# Altium schematic sheet ESP32.SchDoc(ESP32)
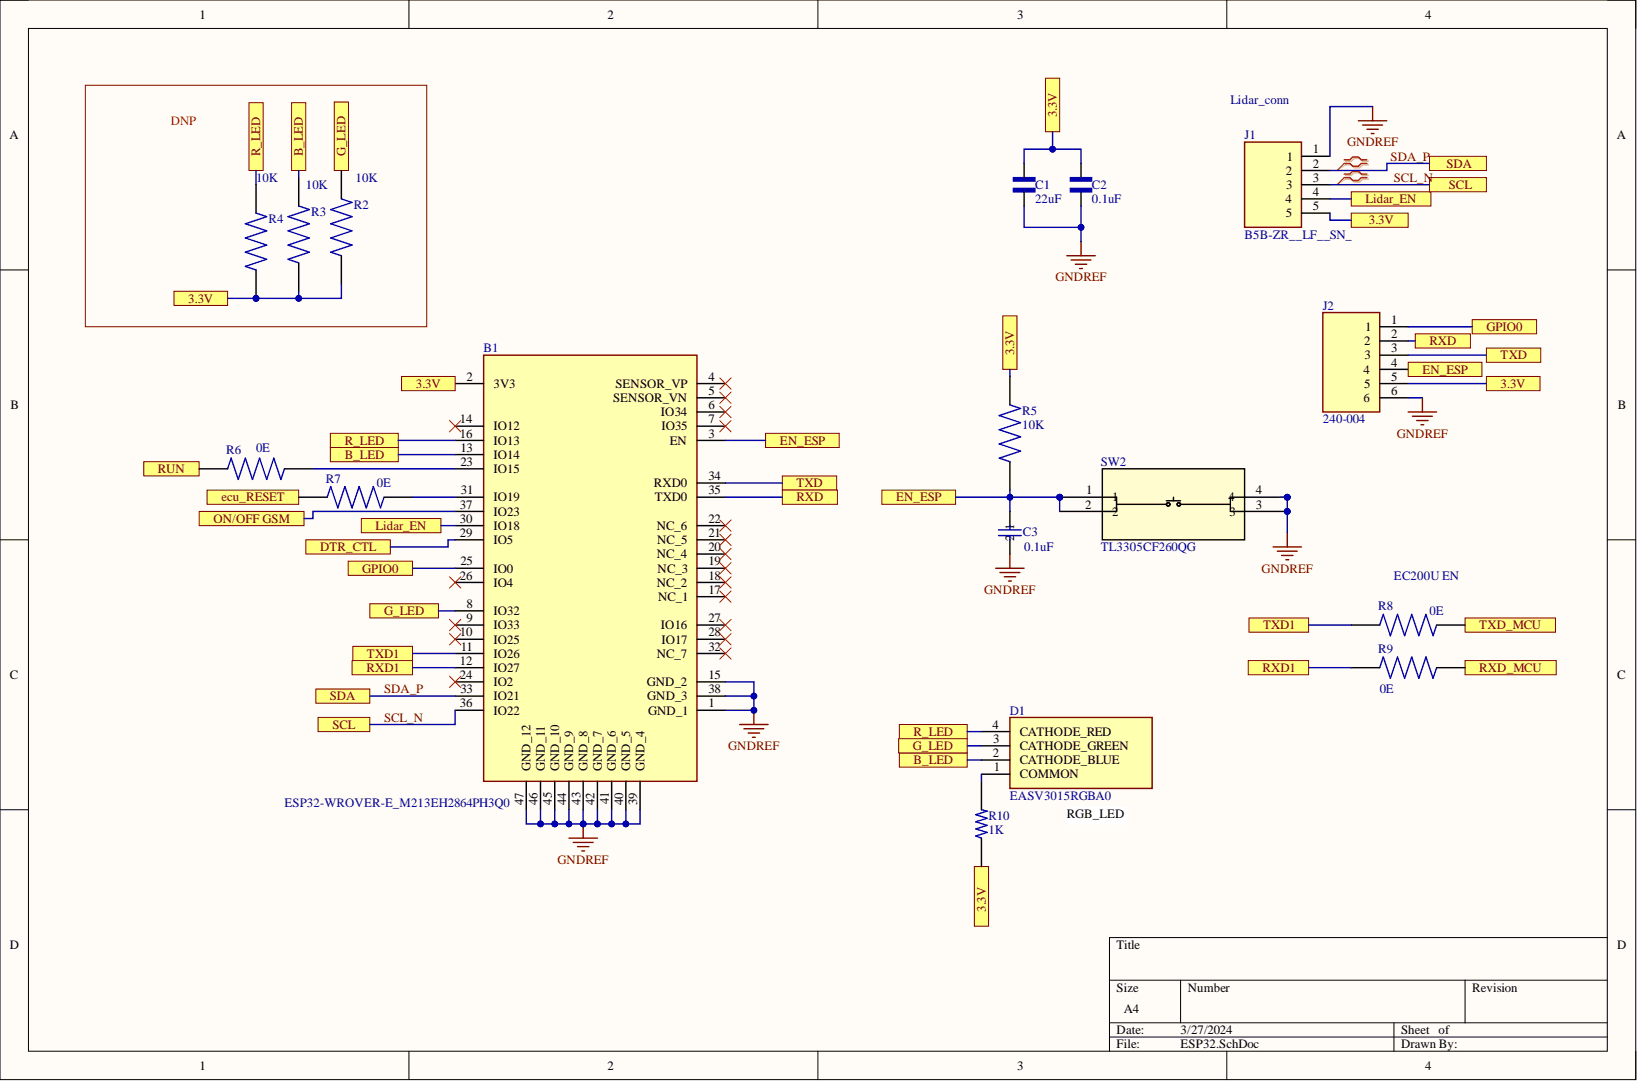
[diagram p0: ESP32.SchDoc]

## Parts
B1  ESP32-WROVER-E_M213EH2864PH3Q0
C1  22uF
C2  0.1uF
C3  0.1uF
D1  EASV3015RGBA0
J1  B5B-ZR__LF__SN_
J2  240-004
R2  10K
R3  10K
R4  10K
R5  10K
R6  0E
R7  0E
R8  0E
R9  0E
R10  1K
SW2  TL3305CF260QG

## Ports
3.3V, B_LED, DTR_CTL, ecu_RESET, EN_ESP, G_LED, GPIO0, Lidar_EN, ON/OFF GSM, R_LED, RUN, RXD, RXD1, RXD_MCU, SCL, SDA, TXD, TXD1, TXD_MCU

## Nets
GNDREF: B1.1(GND_1) B1.15(GND_2) B1.38(GND_3) B1.39(GND_4) B1.40(GND_5) B1.41(GND_6) B1.42(GND_7) B1.43(GND_8) B1.44(GND_9) B1.45(GND_10) B1.46(GND_11) B1.47(GND_12) C1.1 C2.1 C3.1 J1.1 J2.6 SW2.3 SW2.4
3.3V: B1.2(3V3) C1.2 C2.2 J1.5 J2.5 R2.2 R3.2 R4.2 R5.2 R10.1 ; port 3.3V
B_LED: B1.13(IO14) D1.2(CATHODE_BLUE) R3.1 ; port B_LED
DTR_CTL: B1.29(IO5) ; port DTR_CTL
ecu_RESET: R7.1 ; port ecu_RESET
EN_ESP: B1.3(EN) C3.2 J2.4 R5.1 SW2.1 SW2.2 ; port EN_ESP
G_LED: B1.8(IO32) D1.3(CATHODE_GREEN) R2.1 ; port G_LED
GPIO0: B1.25(IO0) J2.1 ; port GPIO0
Lidar_EN: B1.30(IO18) J1.4 ; port Lidar_EN
ON/OFF GSM: B1.37(IO23) ; port ON/OFF GSM
R_LED: B1.16(IO13) D1.4(CATHODE_RED) R4.1 ; port R_LED
RUN: R6.1 ; port RUN
RXD: B1.35(TXD0) J2.2 ; port RXD
RXD1: B1.12(IO27) R9.1 ; port RXD1
RXD_MCU: R9.2 ; port RXD_MCU
SCL_N: B1.36(IO22) J1.3 ; port SCL
SDA_P: B1.33(IO21) J1.2 ; port SDA
TXD: B1.34(RXD0) J2.3 ; port TXD
TXD1: B1.11(IO26) R8.1 ; port TXD1
TXD_MCU: R8.2 ; port TXD_MCU
NetB1_23: B1.23(IO15) R6.2
NetB1_31: B1.31(IO19) R7.2
NetD1_1: D1.1(COMMON) R10.2

Not connected: B1 4(SENSOR_VP) 5(SENSOR_VN) 6(IO34) 7(IO35) 9(IO33) 10(IO25) 14(IO12) 17(NC_1) 18(NC_2) 19(NC_3) 20(NC_4) 21(NC_5) 22(NC_6) 24(IO2) 26(IO4) 27(IO16) 28(IO17) 32(NC_7)

## Library data of the parts
B1 = ESP32-WROVER-E_M213EH2864PH3Q0: WiFi Modules (802.11) SMD Module ESP32-D0WD-V3, 3.3V 64Mbit PSRAM, 16 MB SPI flash, PCB Antenna; Manufacturer_Name: Espressif Systems; Manufacturer_Part_Number: ESP32-WROVER-E(M213EH2864PH3Q0); Geometry.Height: 3.45mm; Component Kind: Standard; Datasheet Link: https://www.mouser.in/datasheet/2/891/esp32-wrover-e_esp32-wrover-ie_datasheet_en-1855913.pdf; Library Name: ESP32-WROVER-E_M213EH2864PH3Q0.SchLib; Mouser Part Number: 356-ESP32WRVE22864PC; Mouser Price/Stock: https://www.mouser.co.uk/ProductDetail/Espressif-Systems/ESP32-WROVER-EM213EH2864PH3Q0?qs=vmHwEFxEFR8kG00CaGIvFQ%3D%3D
C1 = GRM188R61A226ME15D: SMD Capacitor X5R(EIA) with Capacitance: 22uF Tol. 20%. Rated Voltage: 10Vdc; MF: {'manufacturer': u'Murata Electronics'}; Availability: Unavailable; Component Kind: Standard; MP: GRM188R61A226ME15D; Package: None; Price: None
C2 = CL10B104KO8NNNC: MF: {'manufacturer': u'Samsung Electro-Mechanics'}; Availability: Unavailable; Component Kind: Standard; MP: CL10B104KO8NNNC; Package: None; Price: None
C3 = CMP-2007-03455-1: C0805 _0.1uF 15V; Manufacturer: Murata; Part Number: GRM21BR71C225KA12L; Component Kind: Standard; Library Name: Cap_0805.SchLib
D1 = EASV3015RGBA0: Standard LEDs - SMD 3015 PKG-PCB-RGB 624/525/470nm; Manufacturer_Name: Everlight; Geometry.Height: 1.6mm; Arrow Part Number: EASV3015RGBA0; Arrow Price/Stock: https://www.arrow.com/en/products/easv3015rgba0/everlight-electronics?region=nac; Component Kind: Standard; Datasheet Link: https://everlightamericas.com/index.php?controller=attachment&id_attachment=3220; Library Name: EASV3015RGBA0.SchLib; Manufacturer_Part_Number: EASV3015RGBA0; Mouser Part Number: 638-EASV3015RGBA0; Mouser Price/Stock: https://www.mouser.co.uk/ProductDetail/Everlight/EASV3015RGBA0?qs=ZaMXuU%252B6JZZcmAAP09%2FX9w%3D%3D
J1 = B5B-ZR__LF__SN_: Connector,Multipole,PCB use,B5B-ZR (LF)( JST ZH Series, 1.5mm Pitch 5 Way 1 Row Straight PCB Header, Solder Termination, 1A; Manufacturer_Name: JST (JAPAN SOLDERLESS TERMINALS); Manufacturer_Part_Number: B5B-ZR (LF)(SN); Geometry.Height: 4.5mm; Component Kind: Standard; Library Name: B5B-ZR__LF__SN_.SchLib; Mouser Part Number: N/A; Mouser Price/Stock: https://www.mouser.com/Search/Refine.aspx?Keyword=N%2FA
J2 = 240-004: Headers & Wire Housings 6-pin Header & Gender Changer (5-pack); Manufacturer_Name: Digilent, Inc.; Geometry.Height: 9.09mm; Component Kind: Standard; Datasheet Link: https://digilent.com/reference/_media/reference/test-and-measurement/6-pin-header-gender-changer/163-068-2.pdf; Library Name: 240-004.SchLib; Manufacturer_Part_Number: 240-004
R2-R5 = RC0603FR-0710KL: YAGEO RC0603FR-0710KL / RESISTOR 10K 1/10W 1% 0603 YAG <a href="https://pricing.snapeda.com/parts/RC0603FR-0710KL/Yageo/view-part?ref=eda">Check availability</a>; MF: {'manufacturer': u'Yageo'}; Availability: Unavailable; Component Kind: Standard; MP: RC0603FR-0710KL; Package: None; Price: None
R6-R9 = RMCF0603ZT0R00: MF: {'manufacturer': u'Stackpole Electronics'}; Availability: Unavailable; Component Kind: Standard; MP: RMCF0603ZT0R00; Package: None; Price: None
R10 = RC0603FR-071KL: RES SMT 1K 5% 0603 T/R PICK#150742; MF: {'manufacturer': u'Yageo'}; Availability: Unavailable; Component Kind: Standard; MP: RC0603FR-071KL; Package: None; Price: None
SW2 = TL3305CF260QG: No Description Available; Mfr_Name: E-Switch; Component Kind: Standard; Library Name: TL3305CF260QG.SchLib; RefDes: RefDes; Type: Type
